# Altium schematic sheet 两路全隔离型缓存集线器（隔离栅外壳）V2.0.SchDoc
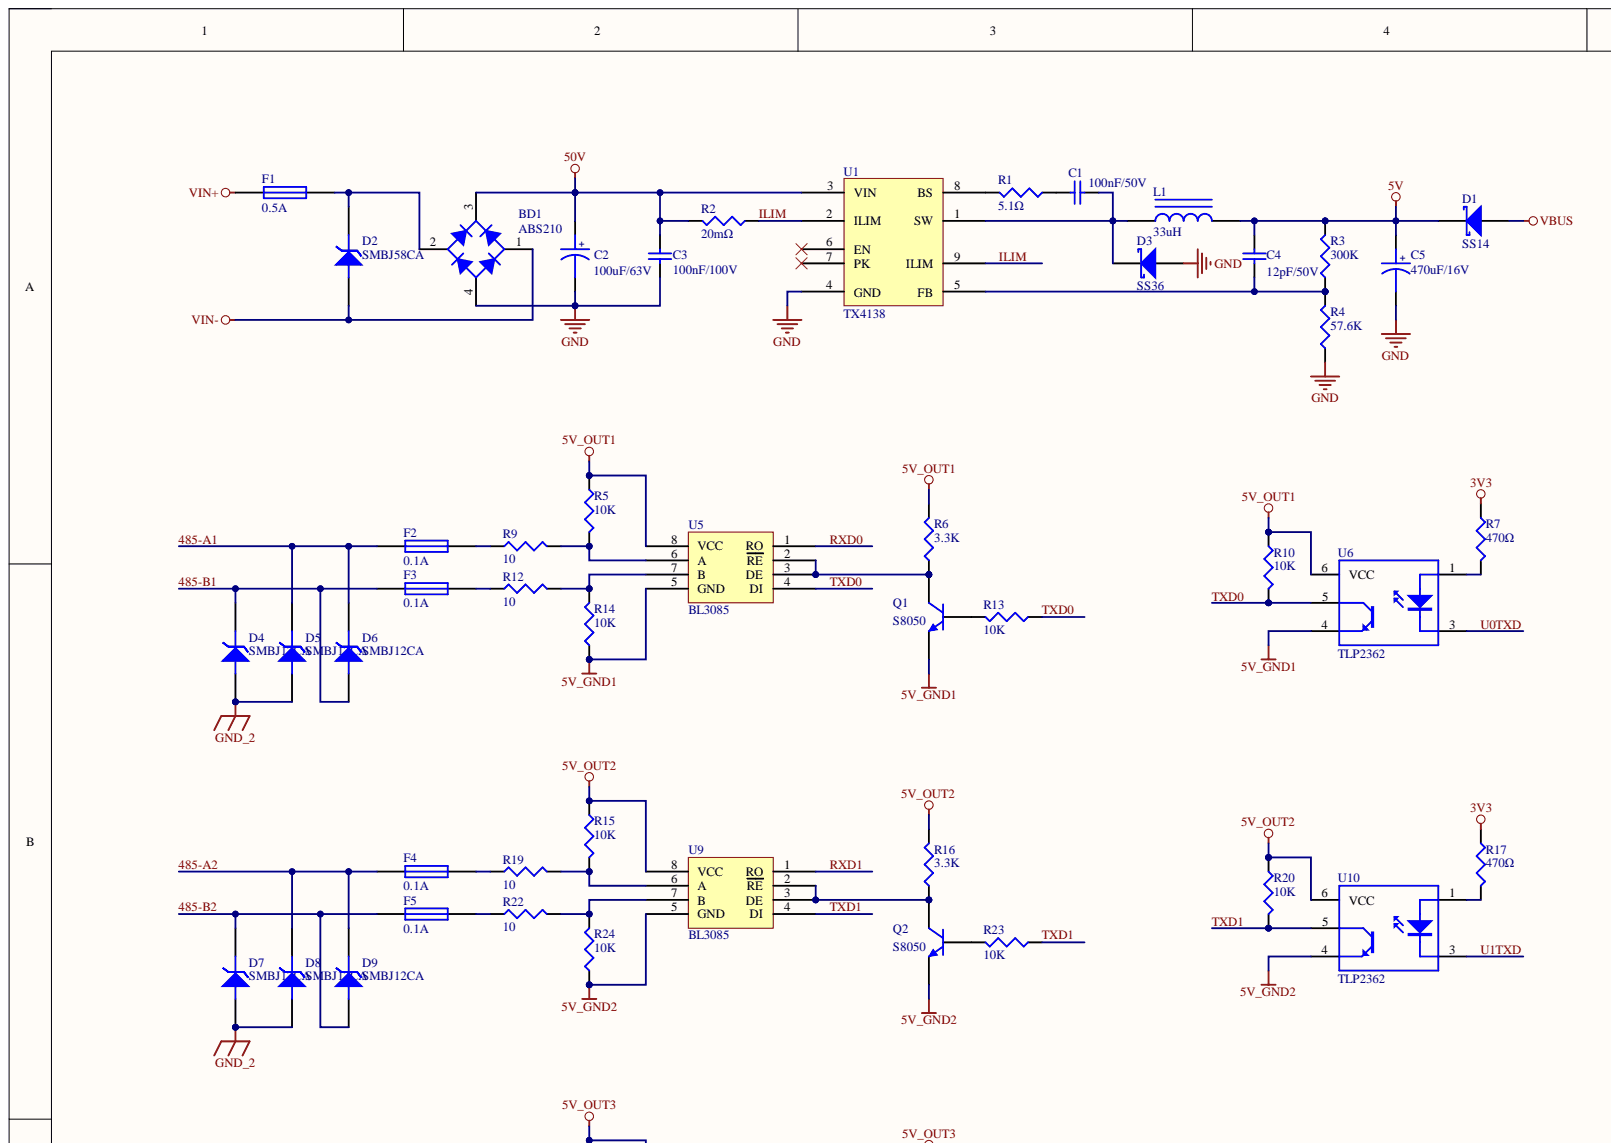
[diagram p0: 两路全隔离型缓存集线器（隔离栅外壳）V2.0.SchDoc, top left]
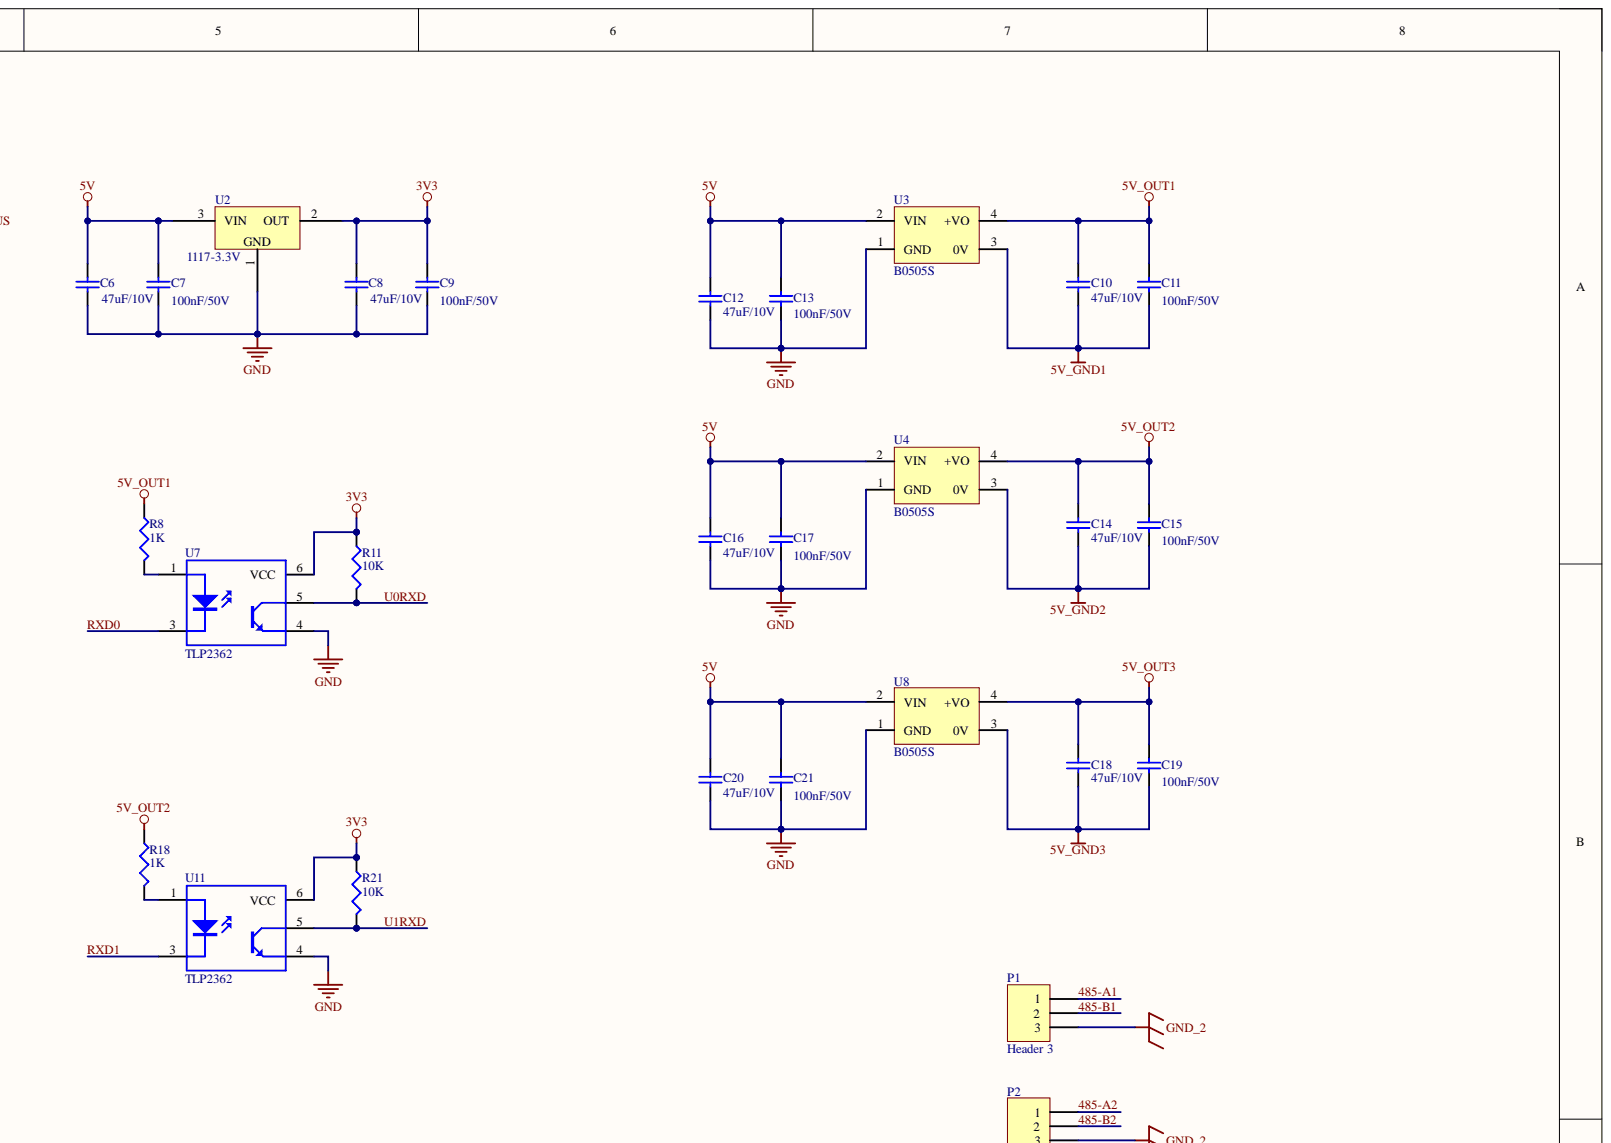
[diagram p1: 两路全隔离型缓存集线器（隔离栅外壳）V2.0.SchDoc, top right]
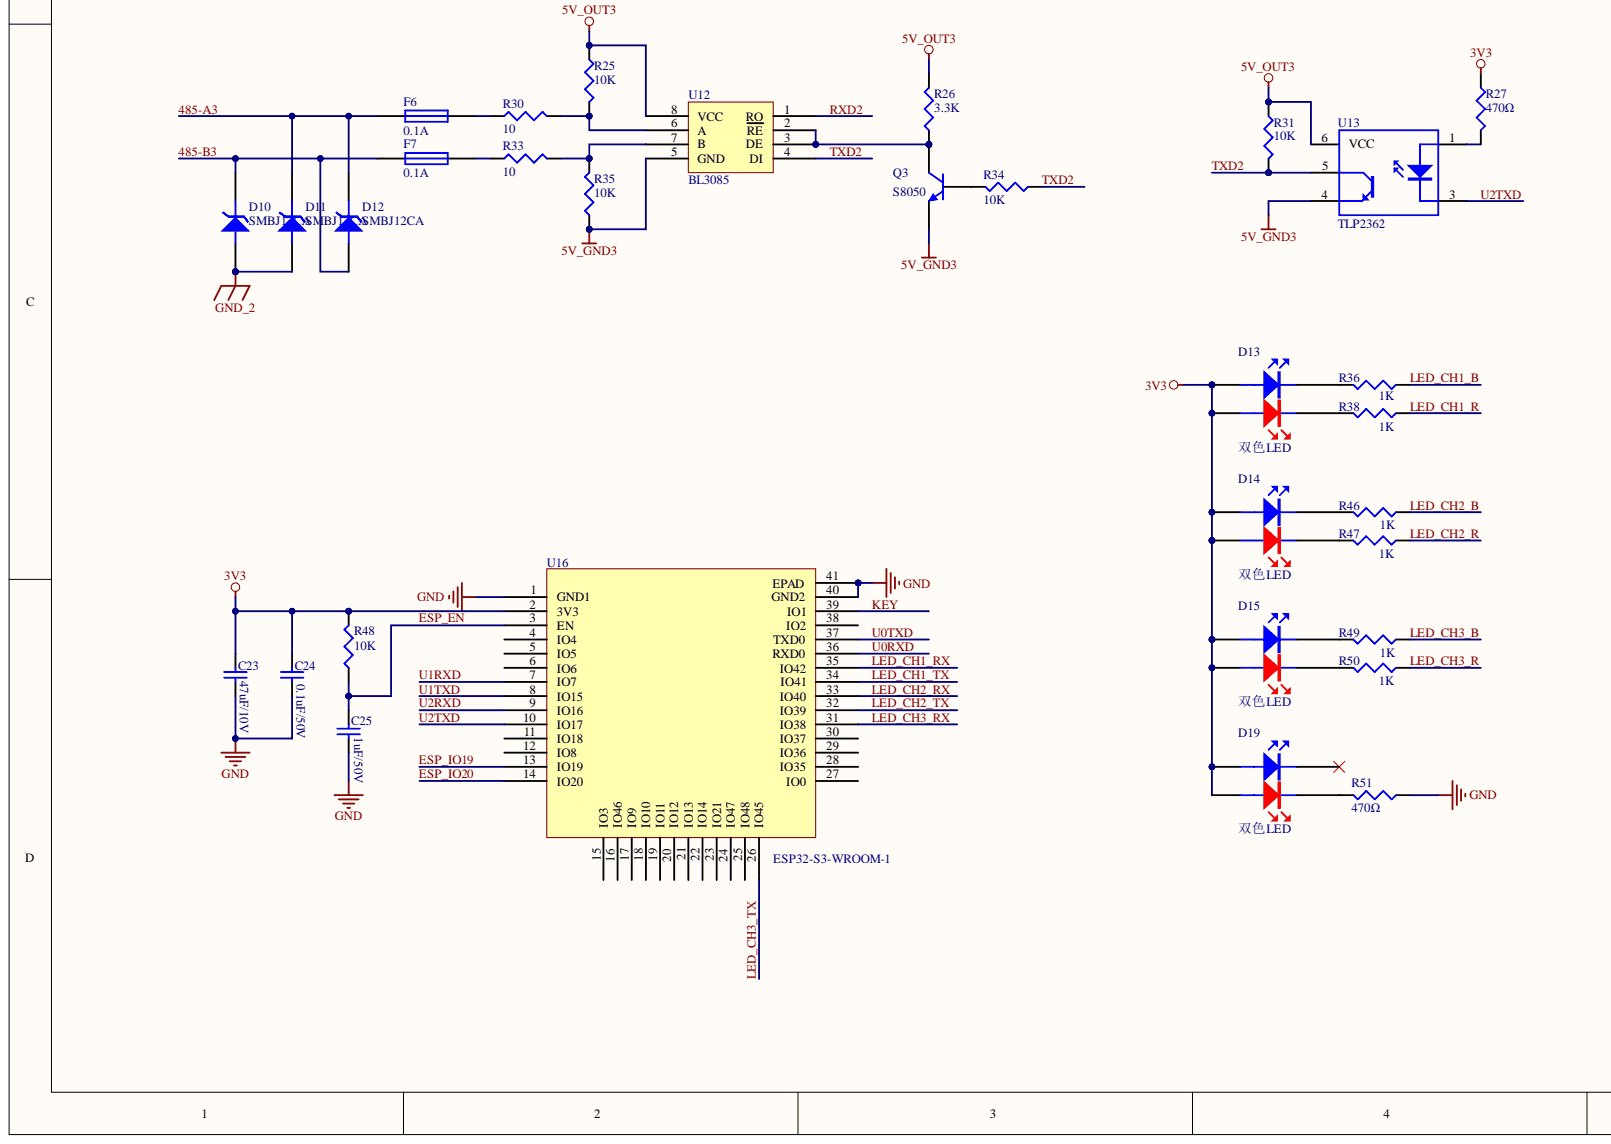
[diagram p2: 两路全隔离型缓存集线器（隔离栅外壳）V2.0.SchDoc, bottom left]
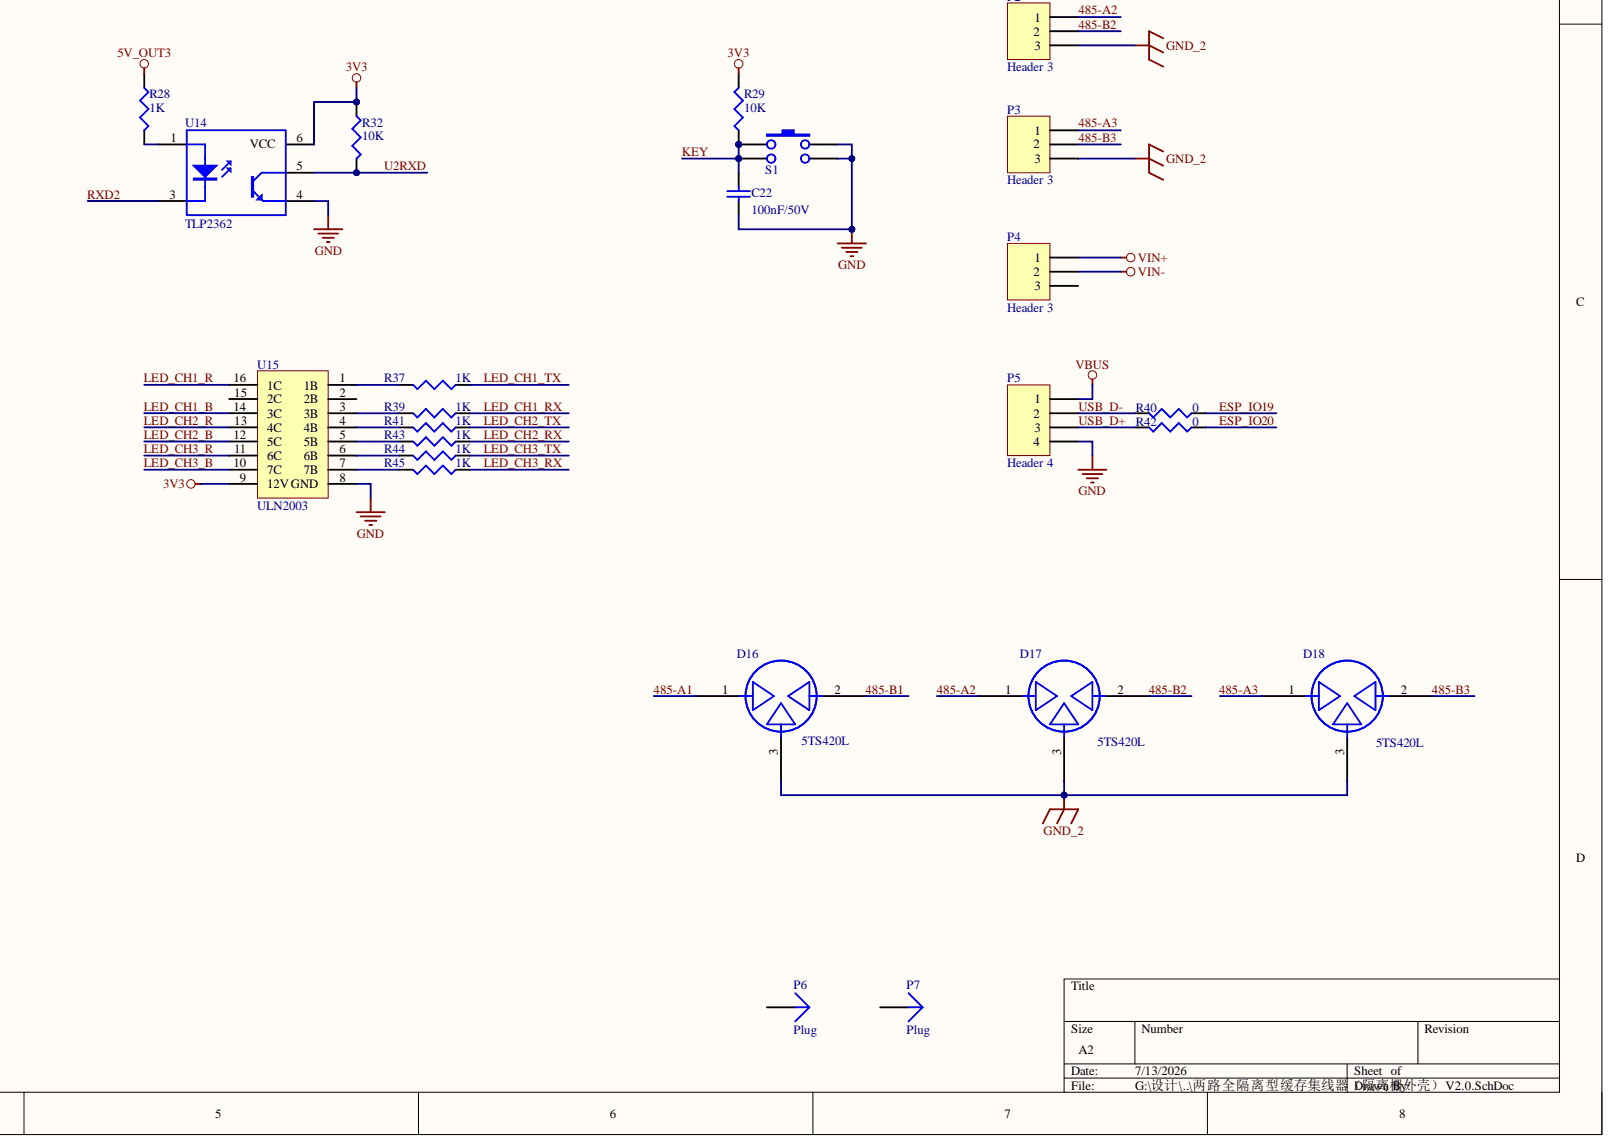
[diagram p3: 两路全隔离型缓存集线器（隔离栅外壳）V2.0.SchDoc, bottom right]

## Parts
BD1  ABS210  [ABS210]
C1  100nF/50V  [C0603]
C2  100uF/63V  [CAP-SMD 10X10]
C3  100nF/100V  [C0603]
C4  12pF/50V  [C0603]
C5  470uF/16V  [CAP-E SMD 6.6X6.6]
C6  47uF/10V  [CAP-1206]
C7  100nF/50V  [C0603]
C8  47uF/10V  [CAP-1206]
C9  100nF/50V  [C0603]
C10  47uF/10V  [C1206]
C11  100nF/50V  [C0603]
C12  47uF/10V  [C1206]
C13  100nF/50V  [C0603]
C14  47uF/10V  [C1206]
C15  100nF/50V  [C0603]
C16  47uF/10V  [C1206]
C17  100nF/50V  [C0603]
C18  47uF/10V  [C1206]
C19  100nF/50V  [C0603]
C20  47uF/10V  [C1206]
C21  100nF/50V  [C0603]
C22  100nF/50V  [C0603]
C23  47uF/10V  [CAP-1206]
C24  0.1uF/50V  [C0603]
C25  1uF/50V  [C0603]
D1  SS14  [DO-214]
D2  SMBJ58CA  [DO-214 SMB]
D3  SS36  [DO-214]
D4  SMBJ12CA  [DO-214 SMB]
D5  SMBJ12CA  [DO-214 SMB]
D6  SMBJ12CA  [DO-214 SMB]
D7  SMBJ12CA  [DO-214 SMB]
D8  SMBJ12CA  [DO-214 SMB]
D9  SMBJ12CA  [DO-214 SMB]
D10  SMBJ12CA  [DO-214 SMB]
D11  SMBJ12CA  [DO-214 SMB]
D12  SMBJ12CA  [DO-214 SMB]
D13  双色LED  [双色发光二极管侧贴]
D14  双色LED  [双色发光二极管侧贴]
D15  双色LED  [双色发光二极管侧贴]
D16  5TS420L  [5TS420L]
D17  5TS420L  [5TS420L]
D18  5TS420L  [5TS420L]
D19  双色LED  [双色发光二极管侧贴]
F1  0.5A  [FUSE-F0805]
F2  0.1A  [FUSE-F0805]
F3  0.1A  [FUSE-F0805]
F4  0.1A  [FUSE-F0805]
F5  0.1A  [FUSE-F0805]
F6  0.1A  [FUSE-F0805]
F7  0.1A  [FUSE-F0805]
L1  33uH  [L6X6]
P1  Header 3  [5.0-3P-C1]
P2  Header 3  [5.0-3P-C1]
P3  Header 3  [5.0-3P-C2]
P4  Header 3  [5.0-3P-C2]
P5  Header 4  [HDR1X4]
P6  Plug  [MAK]
P7  Plug  [MAK]
Q1  S8050  [SOT23-3]
Q2  S8050  [SOT23-3]
Q3  S8050  [SOT23-3]
R1  5.1Ω  [R0603]
R2  20mΩ  [R1206]
R3  300K  [R0603]
R4  57.6K  [R0603]
R5  10K  [R0603]
R6  3.3K  [R0603]
R7  470Ω  [R0603]
R8  1K  [R0603]
R9  10  [R1206]
R10  10K  [R0603]
R11  10K  [R0603]
R12  10  [R1206]
R13  10K  [R0603]
R14  10K  [R0603]
R15  10K  [R0603]
R16  3.3K  [R0603]
R17  470Ω  [R0603]
R18  1K  [R0603]
R19  10  [R1206]
R20  10K  [R0603]
R21  10K  [R0603]
R22  10  [R1206]
R23  10K  [R0603]
R24  10K  [R0603]
R25  10K  [R0603]
R26  3.3K  [R0603]
R27  470Ω  [R0603]
R28  1K  [R0603]
R29  10K  [R0603]
R30  10  [R1206]
R31  10K  [R0603]
R32  10K  [R0603]
R33  10  [R1206]
R34  10K  [R0603]
R35  10K  [R0603]
R36  1K  [R0603]
R37  1K  [R0603]
R38  1K  [R0603]
R39  1K  [R0603]
R40  0  [R0603]
R41  1K  [R0603]
R42  0  [R0603]
R43  1K  [R0603]
R44  1K  [R0603]
R45  1K  [R0603]
R46  1K  [R0603]
R47  1K  [R0603]
R48  10K  [R0603]
R49  1K  [R0603]
R50  1K  [R0603]
R51  470Ω  [R0603]
S1  微动开关-4P  [KEY-DIP4W]  (value not drawn)
U1  TX4138  [SOP8-9]
U2  1117-3.3V  [SOT223]
U3  B0505S  [B0505S]
U4  B0505S  [B0505S]
U5  BL3085  [SOP8]
U6  TLP2362  [SOP5]
U7  TLP2362  [SOP5]
U8  B0505S  [B0505S]
U9  BL3085  [SOP8]
U10  TLP2362  [SOP5]
U11  TLP2362  [SOP5]
U12  BL3085  [SOP8]
U13  TLP2362  [SOP5]
U14  TLP2362  [SOP5]
U15  ULN2003  [SOP16]
U16  ESP32-S3-WROOM-1  [ESP32-S3-WROOM-1]

## Nets
3V3: C8.1 C9.1 C23.1 C24.1 D13.1 D13.3 D14.1 D14.3 D15.1 D15.3 D19.1 D19.3 R7.1 R11.1 R17.1 R21.1 R27.1 R29.2 R32.1 R48.2 U2.2 U7.6(VCC) U11.6(VCC) U14.6(VCC) U15.9(12V) U16.2(3V3)
5V: C4.1 C5.1 C6.1 C7.1 C12.1 C13.1 C16.1 C17.1 C20.1 C21.1 D1.2 L1.2 R3.2 U2.3 U3.2(VIN) U4.2(VIN) U8.2(VIN)
5V_GND1: C10.2 C11.2 Q1.2 R14.1 U3.3(0V) U5.5(GND) U6.4
5V_GND2: C14.2 C15.2 Q2.2 R24.1 U4.3(0V) U9.5(GND) U10.4
5V_GND3: C18.2 C19.2 Q3.2 R35.1 U8.3(0V) U12.5(GND) U13.4
5V_OUT1: C10.1 C11.1 R5.1 R6.2 R8.1 R10.1 U3.4(+VO) U5.8(VCC) U6.6(VCC)
5V_OUT2: C14.1 C15.1 R15.1 R16.2 R18.1 R20.1 U4.4(+VO) U9.8(VCC) U10.6(VCC)
5V_OUT3: C18.1 C19.1 R25.1 R26.2 R28.1 R31.1 U8.4(+VO) U12.8(VCC) U13.6(VCC)
50V: BD1.3 C2.1 C3.1 R2.2 U1.3(VIN)
GND: BD1.4 C2.2 C3.2 C5.2 C6.2 C7.2 C8.2 C9.2 C12.2 C13.2 C16.2 C17.2 C20.2 C21.2 C22.2 C23.2 C24.2 C25.2 D3.1 P5.4 R4.1 R51.2 S1.3 S1.4 U1.4(GND) U2.1 U3.1(GND) U4.1(GND) U7.4 U8.1(GND) U11.4 U14.4 U15.8(GND) U16.1(GND1) U16.40(GND2) U16.41(EPAD)
GND_2: D4.1 D5.1 D7.1 D8.1 D10.1 D11.1 D16.3 D17.3 D18.3 P1.3 P2.3 P3.3
VBUS: D1.1 P5.1
VIN+: F1.1 P4.1
VIN-: BD1.1 D2.1 P4.2
485-A1: D5.2 D6.2 D16.1 F2.1 P1.1
485-A2: D8.2 D9.2 D17.1 F4.1 P2.1
485-A3: D11.2 D12.2 D18.1 F6.1 P3.1
485-B1: D4.2 D6.1 D16.2 F3.1 P1.2
485-B2: D7.2 D9.1 D17.2 F5.1 P2.2
485-B3: D10.2 D12.1 D18.2 F7.1 P3.2
ESP_EN: C25.1 R48.1 U16.3(EN)
ESP_IO19: R40.1 U16.13(IO19)
ESP_IO20: R42.1 U16.14(IO20)
ILIM: R2.1 U1.2(ILIM) U1.9(ILIM)
KEY: C22.1 R29.1 S1.1 S1.2 U16.39(IO1)
LED_CH1_B: R36.2 U15.14(3C)
LED_CH1_R: R38.2 U15.16(1C)
LED_CH1_RX: R39.2 U16.35(IO42)
LED_CH1_TX: R37.2 U16.34(IO41)
LED_CH2_B: R46.2 U15.12(5C)
LED_CH2_R: R47.2 U15.13(4C)
LED_CH2_RX: R43.2 U16.33(IO40)
LED_CH2_TX: R41.2 U16.32(IO39)
LED_CH3_B: R49.2 U15.10(7C)
LED_CH3_R: R50.2 U15.11(6C)
LED_CH3_RX: R45.2 U16.31(IO38)
LED_CH3_TX: R44.2 U16.26(IO45)
RXD0: U5.1(RO) U7.3
RXD1: U9.1(RO) U11.3
RXD2: U12.1(RO) U14.3
TXD0: R10.2 R13.2 U5.4(DI) U6.5
TXD1: R20.2 R23.2 U9.4(DI) U10.5
TXD2: R31.2 R34.2 U12.4(DI) U13.5
U0RXD: R11.2 U7.5 U16.36(RXD0)
U0TXD: U6.3 U16.37(TXD0)
U1RXD: R21.2 U11.5 U16.7(IO7)
U1TXD: U10.3 U16.8(IO15)
U2RXD: R32.2 U14.5 U16.9(IO16)
U2TXD: U13.3 U16.10(IO17)
USB_D+: P5.3 R42.2
USB_D-: P5.2 R40.2
NetBD1_2: BD1.2 D2.2 F1.2
NetC1_1: C1.1 R1.1
NetC1_2: C1.2 D3.2 L1.1 U1.1(SW)
NetC4_2: C4.2 R3.1 R4.2 U1.5(FB)
NetD13_2: D13.2 R36.1
NetD13_4: D13.4 R38.1
NetD14_2: D14.2 R46.1
NetD14_4: D14.4 R47.1
NetD15_2: D15.2 R49.1
NetD15_4: D15.4 R50.1
NetD19_4: D19.4 R51.1
NetF2_2: F2.2 R9.1
NetF3_2: F3.2 R12.1
NetF4_2: F4.2 R19.1
NetF5_2: F5.2 R22.1
NetF6_2: F6.2 R30.1
NetF7_2: F7.2 R33.1
NetQ1_1: Q1.1 R13.1
NetQ1_3: Q1.3 R6.1 U5.2(RE) U5.3(DE)
NetQ2_1: Q2.1 R23.1
NetQ2_3: Q2.3 R16.1 U9.2(RE) U9.3(DE)
NetQ3_1: Q3.1 R34.1
NetQ3_3: Q3.3 R26.1 U12.2(RE) U12.3(DE)
NetR1_2: R1.2 U1.8(BS)
NetR5_2: R5.2 R9.2 U5.6(A)
NetR7_2: R7.2 U6.1
NetR8_2: R8.2 U7.1
NetR12_2: R12.2 R14.2 U5.7(B)
NetR15_2: R15.2 R19.2 U9.6(A)
NetR17_2: R17.2 U10.1
NetR18_2: R18.2 U11.1
NetR22_2: R22.2 R24.2 U9.7(B)
NetR25_2: R25.2 R30.2 U12.6(A)
NetR27_2: R27.2 U13.1
NetR28_2: R28.2 U14.1
NetR33_2: R33.2 R35.2 U12.7(B)
NetR37_1: R37.1 U15.1(1B)
NetR39_1: R39.1 U15.3(3B)
NetR41_1: R41.1 U15.4(4B)
NetR43_1: R43.1 U15.5(5B)
NetR44_1: R44.1 U15.6(6B)
NetR45_1: R45.1 U15.7(7B)

Not connected: D19 2; P4 3; P6 1; P7 1; U1 6(EN) 7(PK); U15 2(2B) 15(2C); U16 4(IO4) 5(IO5) 6(IO6) 11(IO18) 12(IO8) 15(IO3) 16(IO46) 17(IO9) 18(IO10) 19(IO11) 20(IO12) 21(IO13) 22(IO14) 23(IO21) 24(IO47) 25(IO48) 27(IO0) 28(IO35) 29(IO36) 30(IO37) 38(IO2)

## Library data of the parts
BD1 = ABS210: Full Wave Diode Bridge; Component Kind: Standard; DatasheetVersion: E2732B; LatestRevisionDate: 29-May-2009; LatestRevisionNote: Sch pins A & K swapped.; PackageDescription: Bridge Rectifier; 4 Terminals; Body 9.4 x 9.4 mm (typ); Pitch 5.08 mm; PackageReference: D-38; Published: 8-Jun-2000; Simulation: BRIDGE; Library Name: 86WIFI智能开关V1.0.SCHLIB; Publisher: Altium Limited
C1 C4 C7 C9 C11 C13 C15 C17 C19 C21 C22 = Cap: Capacitor; Value: 0.1uF; Component Kind: Standard; LatestRevisionDate: 17-Jul-2002; LatestRevisionNote: Re-released for DXP Platform.; Library Name: Miscellaneous Devices.IntLib; PackageDescription: Radial Cap, Thru-Hole; 2 Leads; 0.3 in Pin Spacing; PackageReference: RAD-0.3; Published: 8-Jun-2000; Signal Integrity: Cap; Simulation: CAP; Publisher: Altium Limited
C2 C5 = 电解电容: Capacitor; Component Kind: Standard; Library Name: 自己的原理图库.SCHLIB
C3 C6 C8 C10 C12 C14 C16 C18 C20 C23-C25 = Cap: Capacitor; Value: 22nF; Component Kind: Standard; LatestRevisionDate: 17-Jul-2002; LatestRevisionNote: Re-released for DXP Platform.; Library Name: Miscellaneous Devices.IntLib; PackageDescription: Radial Cap, Thru-Hole; 2 Leads; 0.3 in Pin Spacing; PackageReference: RAD-0.3; Published: 8-Jun-2000; Signal Integrity: Cap; Simulation: CAP; Publisher: Altium Limited
D1 D3 = D Schottky: Schottky Diode; Code_JEDEC: DO-214-AA; Component Kind: Standard; LatestRevisionDate: 17-Jul-2002; LatestRevisionNote: Re-released for DXP Platform.; Library Name: Miscellaneous Devices.IntLib; PackageDescription: DO-214-AA/SMB; 2 C-Bend Leads; Body 5.3 x 3.6 mm, inc. leads (LxW); PackageReference: SMB; Published: 8-Jun-2000; Signal Integrity: D Schottky; Simulation: SKYDIODE; Publisher: Altium Limited
D2 D4-D12 = ZENER3: Zener Diode; Component Kind: Standard; Library Name: 二极管.SCHLIB
F1-F7 = Fuse 1: Fuse; Component Kind: Standard; LatestRevisionDate: 17-Jul-2002; LatestRevisionNote: Re-released for DXP Platform.; Library Name: Miscellaneous Devices.IntLib; PackageDescription: Thru-Hole; 2 Leads; PackageReference: PIN-W2/E2.8; Published: 8-Jun-2000; Simulation: FUSE; Publisher: Altium Limited
L1 = Inductor Iron: Magnetic-Core Inductor; Value: 10mH; Component Kind: Standard; LatestRevisionDate: 17-Jul-2002; LatestRevisionNote: Re-released for DXP Platform.; Library Name: Miscellaneous Devices.IntLib; PackageDescription: Axial Device, Thru-Hole; 2 Leads; 0.9 in Pin Spacing; PackageReference: AXIAL-0.9; Published: 24-Mar-1999; Signal Integrity: Inductor Iron; Simulation: INDUCTOR; Publisher: Altium Limited
P1-P4 = Header 3: Header, 3-Pin; Component Kind: Standard; LatestRevisionDate: 17-Jul-2002; LatestRevisionNote: Re-released for DXP Platform.; Library Name: Miscellaneous Connectors.IntLib; Signal Integrity: Connector; Publisher: Altium Limited
P5 = Header 4: Header, 4-Pin; Component Kind: Standard; LatestRevisionDate: 17-Jul-2002; LatestRevisionNote: Re-released for DXP Platform.; Library Name: Miscellaneous Connectors.IntLib; Signal Integrity: Connector; Publisher: Altium Limited
Q1-Q3 = NPN: NPN Bipolar Transistor; Code_JEDEC: TO-226-AA; Component Kind: Standard; LatestRevisionDate: 17-Jul-2002; LatestRevisionNote: Re-released for DXP Platform.; PackageDescription: TO, Flat Index; 3 In-Line, Axial Leads; Body Dia. 4.8mm; Leads 0.55 x 0.51 mm (max); PackageReference: TO-226-AA; Published: 8-Jun-2000; Signal Integrity: NPN; Simulation: NPN; Library Name: 自己的原理图库.SCHLIB; Publisher: Altium Limited
R1-R7 R9-R12 R14-R17 R19-R22 R24-R27 R29-R33 R35-R51 = Res3: Resistor; Value: 6.1k; Component Kind: Standard; LatestRevisionDate: 29-May-2009; LatestRevisionNote: IPC-7351 Footprint Added.; PackageDescription: Chip Resistor; PackageReference: J1-0603; Published: 8-Jun-2000; Signal Integrity: Res; Simulation: RESISTOR; Library Name: 自己的原理图库.SCHLIB; Publisher: Altium Limited
R8 R18 R28 = Res3: Resistor; Component Kind: Standard; LatestRevisionDate: 29-May-2009; LatestRevisionNote: IPC-7351 Footprint Added.; Library Name: Miscellaneous Devices.IntLib; PackageDescription: Chip Resistor; PackageReference: J1-0603; Published: 8-Jun-2000; Signal Integrity: Res; Simulation: RESISTOR; Value: 1K; Publisher: Altium Limited
R13 R23 R34 = Res3: Resistor; Value: 1K; Component Kind: Standard; LatestRevisionDate: 29-May-2009; LatestRevisionNote: IPC-7351 Footprint Added.; Library Name: Miscellaneous Devices.IntLib; PackageDescription: Chip Resistor; PackageReference: J1-0603; Published: 8-Jun-2000; Signal Integrity: Res; Simulation: RESISTOR; Publisher: Altium Limited
U5 U9 U12 = SP485EE: Component Kind: Standard; Library Name: 自己的原理图库.SCHLIB
U6 U7 U10 U11 U13 U14 = TLP118: 4-Pin Phototransistor Optocoupler; Component Kind: Standard; DatasheetVersion: Nov-2003; LatestRevisionDate: 04-Mar-2005; LatestRevisionNote: Sch pins 3 & 4 Swapped.; PackageReference: DIP-4; Published: 24-Mar-1999; Library Name: 自己的原理图库.SCHLIB; Publisher: Altium Limited
D13-D15 D19 = 双色LED: Component Kind: Standard; Library Name: Schlib1.SchLib
D16-D18 = 5TS420L: Component Kind: Standard; Library Name: 自己的原理图库.SCHLIB
P6 P7 = Plug: Component Kind: Standard; Description: Plug; LatestRevisionDate: 17-Jul-2002; LatestRevisionNote: Re-released for DXP Platform.; Library Name: Miscellaneous Connectors.IntLib; Signal Integrity: Connector; Publisher: Altium Limited
S1 = 微动开关-4P: Component Kind: Standard; Library Name: 自己的原理图库.SCHLIB
U1 = TX4138: Component Kind: Standard; Library Name: Schlib1.SchLib
U2 = 1117-3.3V: Component Kind: Standard; Library Name: 安全带监测手持机.SchLib
U3 U4 U8 = B0505S: Component Kind: Standard; Library Name: 自己的原理图库.SCHLIB
U15 = ULN2003: Component Kind: Standard; Library Name: 自己的原理图库.SCHLIB
U16 = ESP32-S3-WROOM-1: Component Kind: Standard; Library Name: Schlib1.SchLib
